# Altium schematic sheet STM32F405开发板v2.2_通用接口.SchDoc
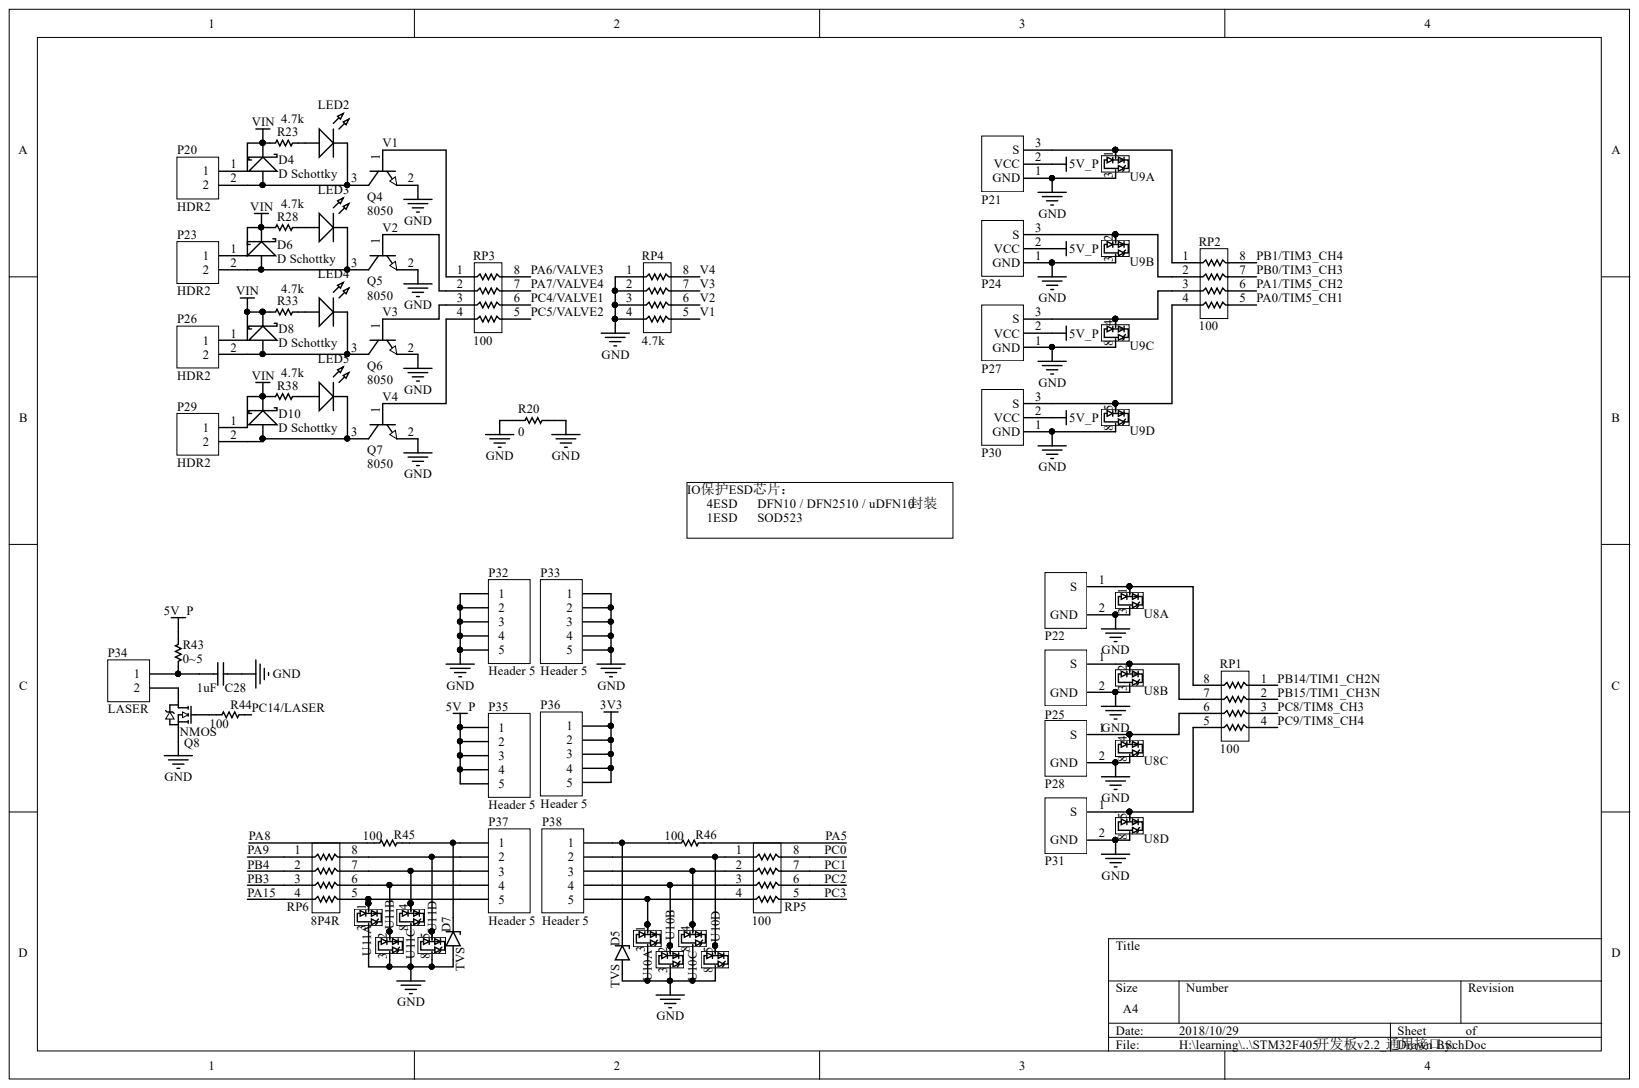
[diagram p0: STM32F405开发板v2.2_通用接口.SchDoc]

## Parts
C28  1uF  [0402]
D4  D Schottky  [SOD-323-]
D5  TVS  [SOD-523]
D6  D Schottky  [SOD-323-]
D7  TVS  [SOD-523]
D8  D Schottky  [SOD-323-]
D10  D Schottky  [SOD-323-]
LED2  LED_RED  [0603LED_B]  (value not drawn)
LED3  LED_RED  [0603LED_B]  (value not drawn)
LED4  LED_RED  [0603LED_B]  (value not drawn)
LED5  LED_RED  [0603LED_B]  (value not drawn)
P20  HDR2  [HY2.00MM 2X1_立式]
P21  3Pin_IO  [HY2.00MM 3x1立式]  (value not drawn)
P22  2pin_IO  [HY2.00MM 2X1_立式]  (value not drawn)
P23  HDR2  [HY2.00MM 2X1_立式]
P24  3Pin_IO  [HY2.00MM 3x1立式]  (value not drawn)
P25  2pin_IO  [HY2.00MM 2X1_立式]  (value not drawn)
P26  HDR2  [HY2.00MM 2X1_立式]
P27  3Pin_IO  [HY2.00MM 3x1立式]  (value not drawn)
P28  2pin_IO  [HY2.00MM 2X1_立式]  (value not drawn)
P29  HDR2  [HY2.00MM 2X1_立式]
P30  3Pin_IO  [HY2.00MM 3x1立式]  (value not drawn)
P31  2pin_IO  [HY2.00MM 2X1_立式]  (value not drawn)
P32  Header 5  [HEADER1X5]
P33  Header 5  [HEADER1X5]
P34  LASER  [HY2.00MM 2X1_立式]
P35  Header 5  [HEADER1X5]
P36  Header 5  [HEADER1X5]
P37  Header 5  [HEADER1X5]
P38  Header 5  [HEADER1X5]
Q4  8050  [SOT-323]
Q5  8050  [SOT-323]
Q6  8050  [SOT-323]
Q7  8050  [SOT-323]
Q8  NMOS  [SOT-23-3]
R20  0  [0603R]
R23  4.7k  [0402R]
R28  4.7k  [0402R]
R33  4.7k  [0402R]
R38  4.7k  [0402R]
R43  0~5  [0603R]
R44  100  [0402R]
R45  100  [0402R]
R46  100  [0402R]
RP1  100  [RES-8P4R-0402]
RP2  100  [RES-8P4R-0402]
RP3  100  [RES-8P4R-0402]
RP4  4.7k  [RES-8P4R-0402]
RP5  100  [RES-8P4R-0402]
RP6  8P4R  [RES-8P4R-0402]
U8  ESD-4channels  [DQA0010A]  (value not drawn)  (parts A,B,C,D)
U9  ESD-4channels  [DQA0010A]  (value not drawn)  (parts A,B,C,D)
U10  ESD-4channels  [DQA0010A]  (value not drawn)  (parts A,B,C,D)
U11  ESD-4channels  [DQA0010A]  (value not drawn)  (parts A,B,C,D)

## Nets
3V3: P36.1 P36.2 P36.3 P36.4 P36.5
5V_P: P21.2 P24.2 P27.2 P30.2 P35.1 P35.2 P35.3 P35.4 P35.5 R43.1
GND: C28.2 D5.1 D7.1 P21.1 P22.2 P24.1 P25.2 P27.1 P28.2 P30.1 P31.2 P32.1 P32.2 P32.3 P32.4 P32.5 P33.1 P33.2 P33.3 P33.4 P33.5 Q4.2 Q5.2 Q6.2 Q7.2 Q8.2 R20.1 R20.2 RP4.1 RP4.2 RP4.3 RP4.4 U8.3 U8.8 U9.3 U9.8 U10.3 U10.8 U11.3 U11.8
VIN: D4.2 D6.2 D8.2 D10.2 P20.1 P23.1 P26.1 P29.1 R23.2 R28.2 R33.2 R38.2
PA0/TIM5_CH1: RP2.5
PA1/TIM5_CH2: RP2.6
PA5: R46.1
PA6/VALVE3: RP3.8
PA7/VALVE4: RP3.7
PA8: R45.2
PA9: RP6.1
PA15: RP6.4
PB0/TIM3_CH3: RP2.7
PB1/TIM3_CH4: RP2.8
PB3: RP6.3
PB4: RP6.2
PB14/TIM1_CH2N: RP1.1
PB15/TIM1_CH3N: RP1.2
PC0: RP5.8
PC1: RP5.7
PC2: RP5.6
PC3: RP5.5
PC4/VALVE1: RP3.6
PC5/VALVE2: RP3.5
PC8/TIM8_CH3: RP1.3
PC9/TIM8_CH4: RP1.4
PC14/LASER: R44.1
V1: Q4.1 RP3.1 RP4.5
V2: Q5.1 RP3.2 RP4.6
V3: Q6.1 RP3.3 RP4.7
V4: Q7.1 RP3.4 RP4.8
NetC28_1: C28.1 P34.1 R43.2
NetD4_1: D4.1 LED2.2 P20.2 Q4.3
NetD5_2: D5.2 P38.1 R46.2
NetD6_1: D6.1 LED3.2 P23.2 Q5.3
NetD7_2: D7.2 P37.1 R45.1
NetD8_1: D8.1 LED4.2 P26.2 Q6.3
NetD10_1: D10.1 LED5.2 P29.2 Q7.3
NetLED2_1: LED2.1 R23.1
NetLED3_1: LED3.1 R28.1
NetLED4_1: LED4.1 R33.1
NetLED5_1: LED5.1 R38.1
NetP21_3: P21.3 RP2.1 U9.1 U9.10
NetP22_1: P22.1 RP1.8 U8.1 U8.10
NetP24_3: P24.3 RP2.2 U9.2 U9.9
NetP25_1: P25.1 RP1.7 U8.2 U8.9
NetP27_3: P27.3 RP2.3 U9.4 U9.7
NetP28_1: P28.1 RP1.6 U8.4 U8.7
NetP30_3: P30.3 RP2.4 U9.5 U9.6
NetP31_1: P31.1 RP1.5 U8.5 U8.6
NetP34_2: P34.2 Q8.3
NetP37_2: P37.2 RP6.8 U11.5 U11.6
NetP37_3: P37.3 RP6.7 U11.4 U11.7
NetP37_4: P37.4 RP6.6 U11.2 U11.9
NetP37_5: P37.5 RP6.5 U11.1 U11.10
NetP38_2: P38.2 RP5.1 U10.5 U10.6
NetP38_3: P38.3 RP5.2 U10.4 U10.7
NetP38_4: P38.4 RP5.3 U10.2 U10.9
NetP38_5: P38.5 RP5.4 U10.1 U10.10
NetQ8_1: Q8.1 R44.2

## Library data of the parts
C28 = Cap: Capacitor; Value: 100pF; Component Kind: Standard; LatestRevisionDate: 17-Jul-2002; LatestRevisionNote: Re-released for DXP Platform.; Library Name: MyComponents_v2.4.IntLib; PackageDescription: Radial Cap, Thru-Hole; 2 Leads; 0.3 in Pin Spacing; PackageReference: RAD-0.3; Published: 8-Jun-2000; Signal Integrity: Cap; Simulation: CAP; Publisher: Altium Limited
D4 D6 D8 D10 = D Schottky: Schottky Diode; Code_JEDEC: DO-214-AA; Component Kind: Standard; LatestRevisionDate: 17-Jul-2002; LatestRevisionNote: Re-released for DXP Platform.; Library Name: MyComponents_v2.4.IntLib; PackageDescription: DO-214-AA/SMB; 2 C-Bend Leads; Body 5.3 x 3.6 mm, inc. leads (LxW); PackageReference: SMB; Published: 8-Jun-2000; Signal Integrity: D Schottky; Simulation: SKYDIODE; Publisher: Altium Limited
D5 D7 = TVS_SOD: Component Kind: Standard; Library Name: MyComponents_v2.4.IntLib
LED2-LED5 = LED: Typical RED, GREEN, YELLOW, AMBER GaAs LED; Component Kind: Standard; LatestRevisionDate: 17-Jul-2002; LatestRevisionNote: Re-released for DXP Platform.; Library Name: MyComponents_v2.4.IntLib; PackageDescription: SM LED; 2 Flat Leads; PackageReference: 3.2X1.6X1.1; PackageVersion: Feb-2002; Published: 8-Jun-2000; Simulation: LED2; Publisher: Altium Limited
P20 P23 P26 P29 = MX3.0_2X1: Component Kind: Standard; Library Name: MyComponents_v2.4.IntLib
P21 P24 P27 P30 = 3Pin_IO: 3pin input/output. V+S+G; Component Kind: Standard; Library Name: MyComponents_v2.4.IntLib
P32 P33 P35-P38 = Header 5: Header, 5-Pin; Component Kind: Standard; LatestRevisionDate: 17-Jul-2002; LatestRevisionNote: Re-released for DXP Platform.; Library Name: MyComponents_v2.4.IntLib; Signal Integrity: Connector; Publisher: Altium Limited
P34 = Header 2: Header, 2-Pin; Component Kind: Standard; LatestRevisionDate: 17-Jul-2002; LatestRevisionNote: Re-released for DXP Platform.; Library Name: Miscellaneous Connectors.IntLib; Signal Integrity: Connector; Publisher: Altium Limited
Q4-Q7 = NPN: NPN Bipolar Transistor; Code_JEDEC: TO-226-AA; Component Kind: Standard; LatestRevisionDate: 17-Jul-2002; LatestRevisionNote: Re-released for DXP Platform.; Library Name: MyComponents_v2.4.IntLib; PackageDescription: TO, Flat Index; 3 In-Line, Axial Leads; Body Dia. 4.8mm; Leads 0.55 x 0.51 mm (max); PackageReference: TO-226-AA; Published: 8-Jun-2000; Signal Integrity: NPN; Simulation: NPN; Publisher: Altium Limited
Q8 = NMOS(SOT23): N-Channel Power MOSFET; Note: Pin 2 is electrically connected to pin 4.; Component Kind: Standard; ComponentLink1Description: Manufacturer Link; ComponentLink1URL: http://www.irf.com/; DatasheetVersion: PD-9.814; LatestRevisionDate: 17-Jul-2002; LatestRevisionNote: Re-released for DXP Platform.; Library Name: MyComponents_v2.4.IntLib; PackageDescription: TO, Thru-Hole, Vertical, Heatsink Mounted; 3 In-Line Leads; Pitch 2.54 mm; PackageReference: TO-220AB; PackageVersion: Feb-1997; Published: 8-Jun-2000; Publisher: Altium Limited
R20 R23 R28 R33 R38 R43-R46 = Res1: Resistor; Value: 1K; Component Kind: Standard; LatestRevisionDate: 17-Jul-2002; LatestRevisionNote: Re-released for DXP Platform.; Library Name: MyComponents_v2.4.IntLib; PackageDescription: Axial Device, Thru-Hole; 2 Leads; 0.3 in Pin Spacing; PackageReference: AXIAL-0.3; Published: 8-Jun-2000; Signal Integrity: Res; Simulation: RESISTOR; Publisher: Altium Limited
RP1-RP5 = Res-8P4R: 8P4R; Component Kind: Standard; Library Name: MyComponents_v2.4.IntLib
RP6 = Res-8P4R: Component Kind: Standard; Description: 8P4R; Library Name: MyComponents_v2.4.IntLib
U8-U11 = ESD-4channels: Imported; Manufacturer: Texas Instruments; PartNumber: TPD4E05U06DQAR; CompType: IC; PIN_COUNT: 10; Component Kind: Standard; Library Name: MyComponents_v2.4.IntLib; MountType: SMT; PackageReference: DQA0010A; RefDes: RefDes; RoHS: Y; TYPE: TYPE; Value: Value
P22 P25 P28 P31 = 2pin_IO: Component Kind: Standard; Library Name: MyComponents_v2.4.IntLib
